# Altium schematic sheet CJMCU-1293.SchDoc(CJMCU-1293)
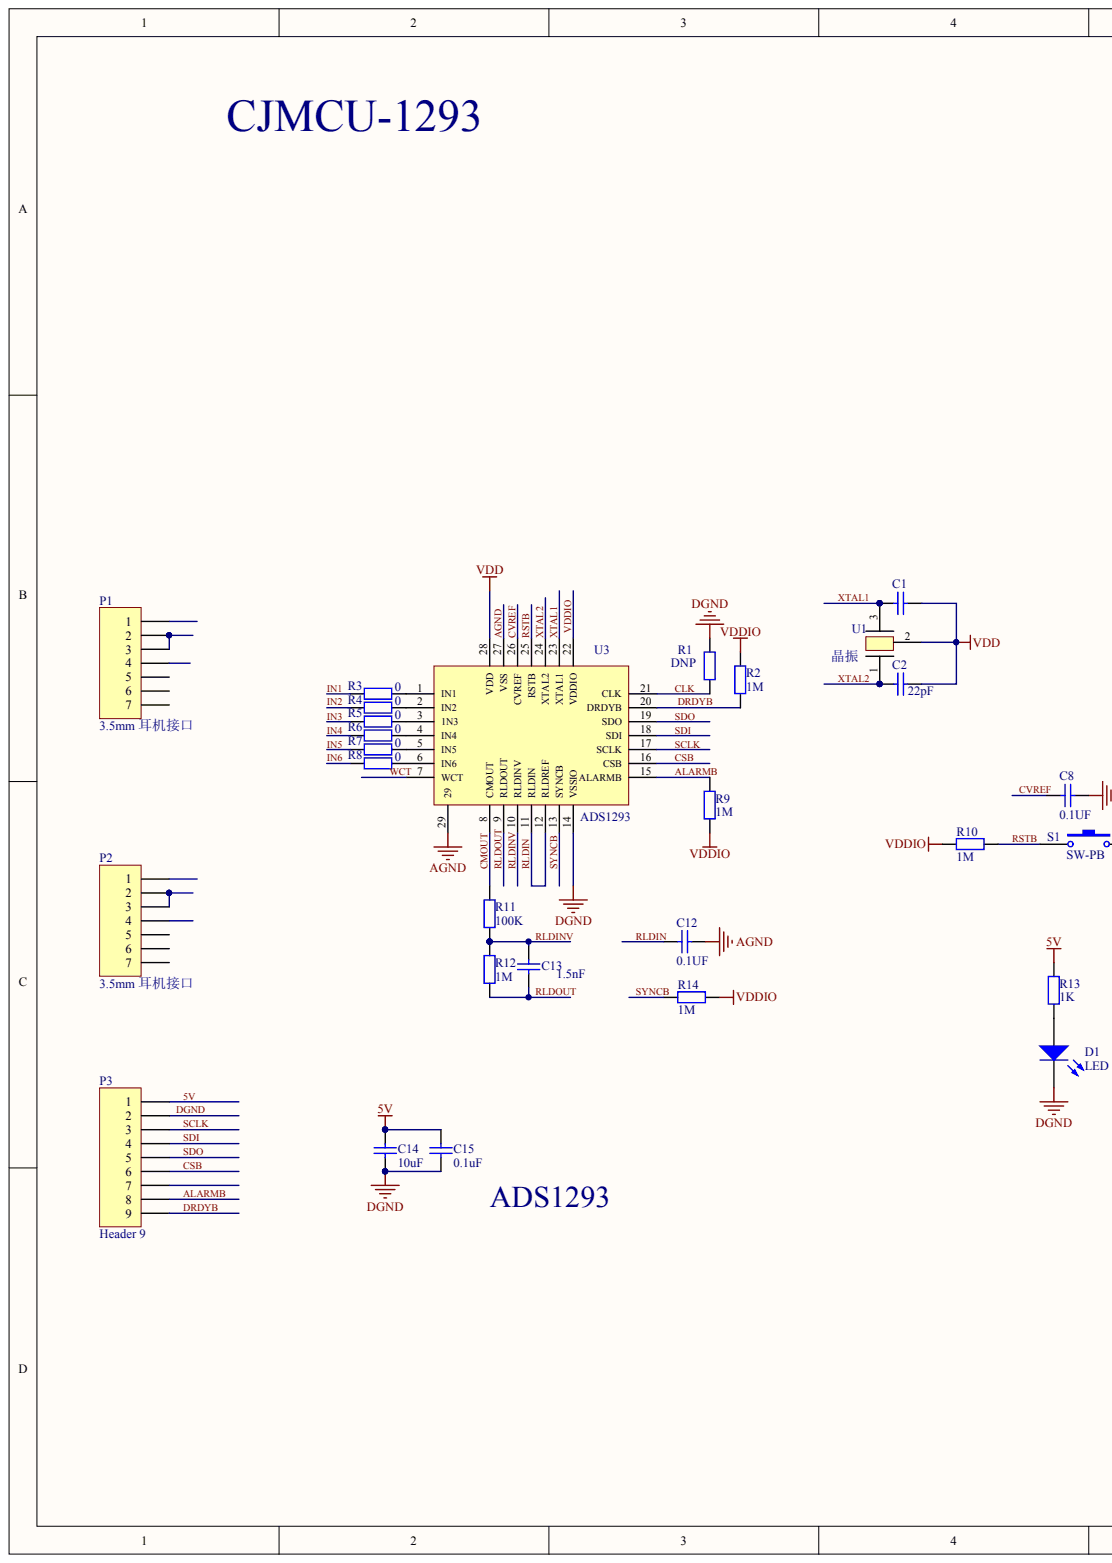
[diagram p0: CJMCU-1293.SchDoc, left]
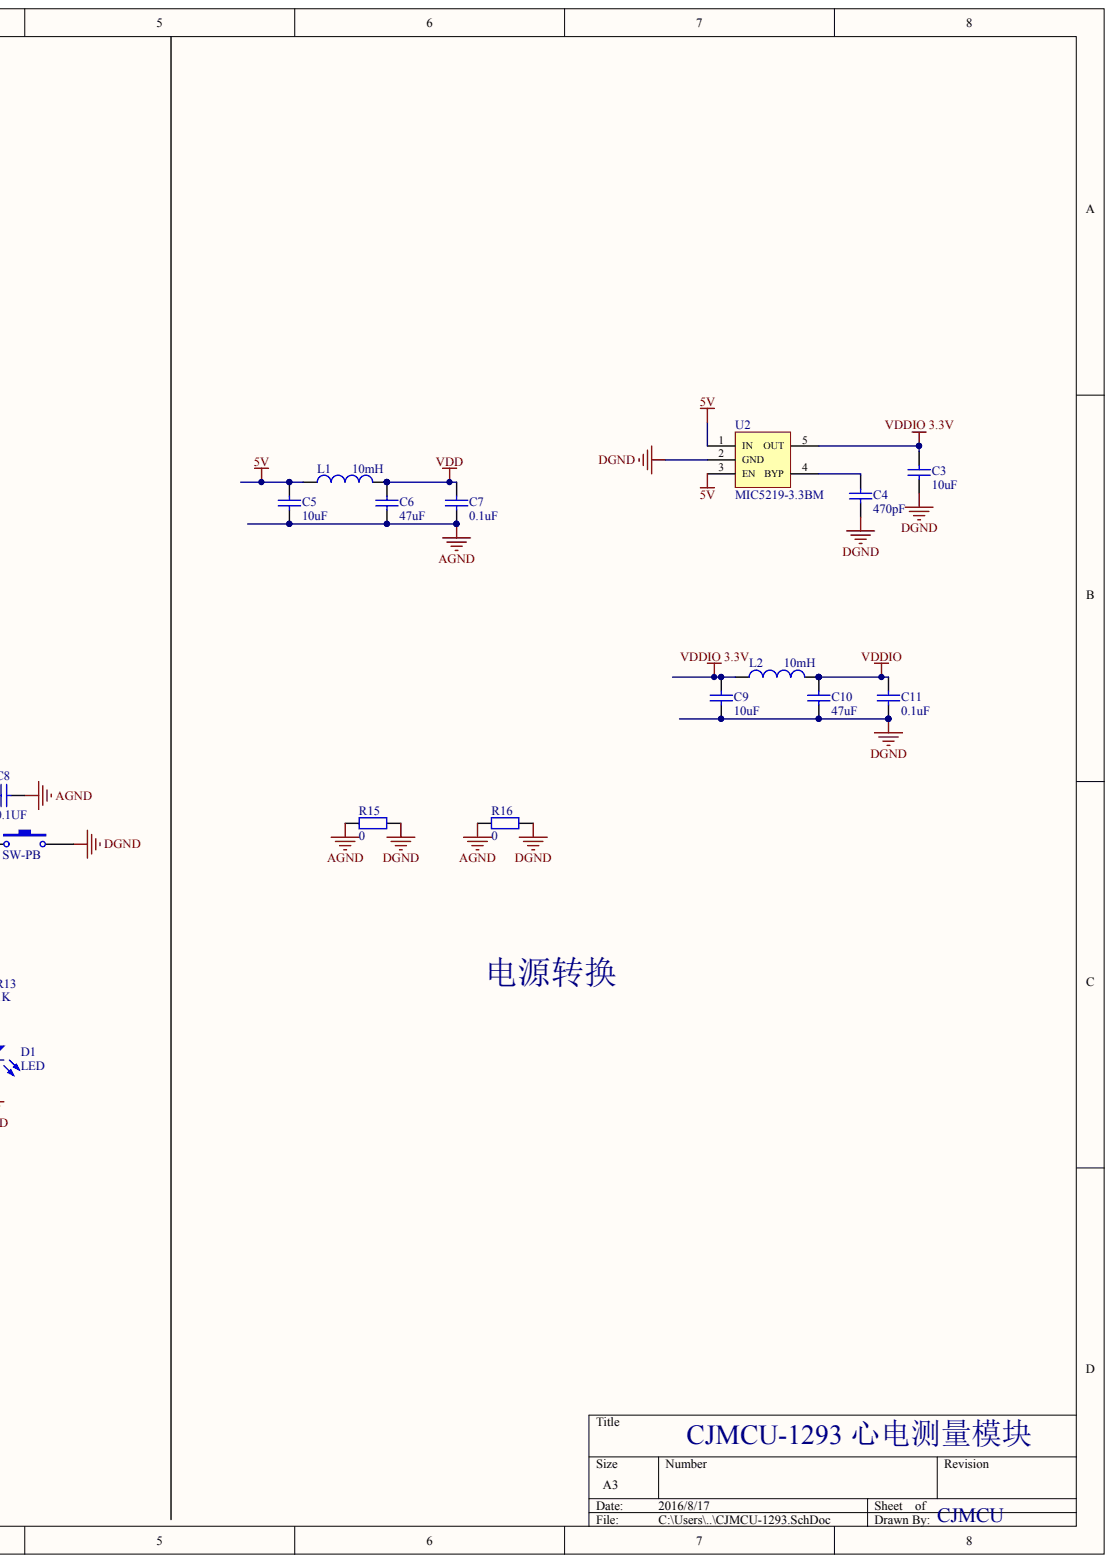
[diagram p1: CJMCU-1293.SchDoc, right]

## Parts
C1  value 22pF  (hidden comment: Cap)
C2  value 22pF  (hidden comment: Cap)
C3  value 10uF  (hidden comment: Cap)
C4  value 470pF  (hidden comment: Cap)
C5  value 10uF  (hidden comment: Cap)
C6  value 47uF  (hidden comment: Cap)
C7  value 0.1uF  (hidden comment: Cap)
C8  value 0.1UF  (hidden comment: Cap)
C9  value 10uF  (hidden comment: Cap)
C10  value 47uF  (hidden comment: Cap)
C11  value 0.1uF  (hidden comment: Cap)
C12  value 0.1UF  (hidden comment: Cap)
C13  value 1.5nF  (hidden comment: Cap)
C14  value 10uF  (hidden comment: Cap)
C15  value 0.1uF  (hidden comment: Cap)
D1  LED
L1  value 10mH  (hidden comment: Inductor)
L2  value 10mH  (hidden comment: Inductor)
P1  3.5mm 耳机接口
P2  3.5mm 耳机接口
P3  Header 9
R1  value DNP  (hidden comment: Res2)
R2  value 1M  (hidden comment: Res2)
R3  value 0  (hidden comment: Res2)
R4  value 0  (hidden comment: Res2)
R5  value 0  (hidden comment: Res2)
R6  value 0  (hidden comment: Res2)
R7  value 0  (hidden comment: Res2)
R8  value 0  (hidden comment: Res2)
R9  value 1M  (hidden comment: Res2)
R10  value 1M  (hidden comment: Res2)
R11  value 100K  (hidden comment: Res2)
R12  value 1M  (hidden comment: Res2)
R13  value 1K  (hidden comment: Res2)
R14  value 1M  (hidden comment: Res2)
R15  value 0  (hidden comment: Res2)
R16  value 0  (hidden comment: Res2)
S1  SW-PB
U1  晶振
U2  MIC5219-3.3BM
U3  ADS1293

## Nets
VDD: C1.2 C2.2 C6.1 C7.2 L1.2 U1.2 U3.28
VDDIO 3.3V: C3.2 C9.2 L2.1 U2.5
5V: C5.2 C14.1 C15.1 L1.1 P3.1 R13.2 U2.1 U2.3
AGND: C5.1 C6.2 C7.1 C8.1 C12.1 R15.1 R16.1 U3.27 U3.29
ALARMB: P3.8 R9.1 U3.15
CLK: R1.1 U3.21
CMOUT: R11.2 U3.8
CSB: P3.6 U3.16
CVREF: C8.2 U3.26
DGND: C3.1 C4.1 C9.1 C10.2 C11.1 C14.2 C15.2 D1.2 P3.2 R1.2 R15.2 R16.2 S1.2 U2.2 U3.14
DRDYB: P3.9 R2.1 U3.20
IN1: P1.1 R3.2
IN2: P1.2 P1.3 R4.2
IN3: P1.4 R5.2
IN4: P2.1 R6.2
IN5: P2.2 P2.3 R7.2
IN6: P2.4 R8.2
RLDIN: C12.2 U3.11 U3.12
RLDINV: C13.2 R11.1 R12.2 U3.10
RLDOUT: C13.1 R12.1 U3.9
RSTB: R10.2 S1.1 U3.25
SCLK: P3.3 U3.17
SDI: P3.4 U3.18
SDO: P3.5 U3.19
SYNCB: R14.1 U3.13
VDDIO: C10.1 C11.2 L2.2 R2.2 R9.2 R10.1 R14.2 U3.22
WCT: P3.7 U3.7
XTAL1: C1.1 U1.3 U3.23
XTAL2: C2.1 U1.1 U3.24
NetC4_2: C4.2 U2.4
NetD1_1: D1.1 R13.1
NetR3_1: R3.1 U3.1
NetR4_1: R4.1 U3.2
NetR5_1: R5.1 U3.3
NetR6_1: R6.1 U3.4
NetR7_1: R7.1 U3.5
NetR8_1: R8.1 U3.6

Not connected: P1 5 6 7; P2 5 6 7

## Library data of the parts
L1 L2 = (no symbol name): Code_IEC: 1005; Published: 8-Jun-2000; LatestRevisionDate: 29-May-2009; LatestRevisionNote: IPC-7351 Footprint Added.; PackageReference: 0402-A; PackageDescription: Chip Inductor; Code_JEITA: 0402; Publisher: Altium Limited
C1-C15 D1 P1-P3 R1-R16 S1 U1-U3 = (no symbol name)
  C1-C15: Published: 8-Jun-2000; LatestRevisionDate: 17-Jul-2002; LatestRevisionNote: Re-released for DXP Platform.; PackageReference: RAD-0.3; PackageDescription: Radial Cap, Thru-Hole; 2 Leads; 0.3 in Pin Spacing
  D1: Published: 8-Jun-2000; LatestRevisionDate: 17-Jul-2002; LatestRevisionNote: Re-released for DXP Platform.; PackageVersion: Feb-2002; PackageReference: 3.2X1.6X1.1; PackageDescription: SM LED; 2 Flat Leads
  P1-P3: LatestRevisionDate: 17-Jul-2002; LatestRevisionNote: Re-released for DXP Platform.
  R1-R16: Published: 8-Jun-2000; LatestRevisionDate: 17-Jul-2002; LatestRevisionNote: Re-released for DXP Platform.; PackageReference: AXIAL-0.4; PackageDescription: Axial Device, Thru-Hole; 2 Leads; 0.4 in Pin Spacing
  S1: Published: 24-Mar-1999; LatestRevisionDate: 17-Jul-2002; LatestRevisionNote: Re-released for DXP Platform.; PackageReference: SPST-2; PackageDescription: Switch; 2 Leads
  C1-C15 D1 P1-P3 R1-R16 S1: Publisher: Altium Limited
